# Altium schematic sheet User.SchDoc
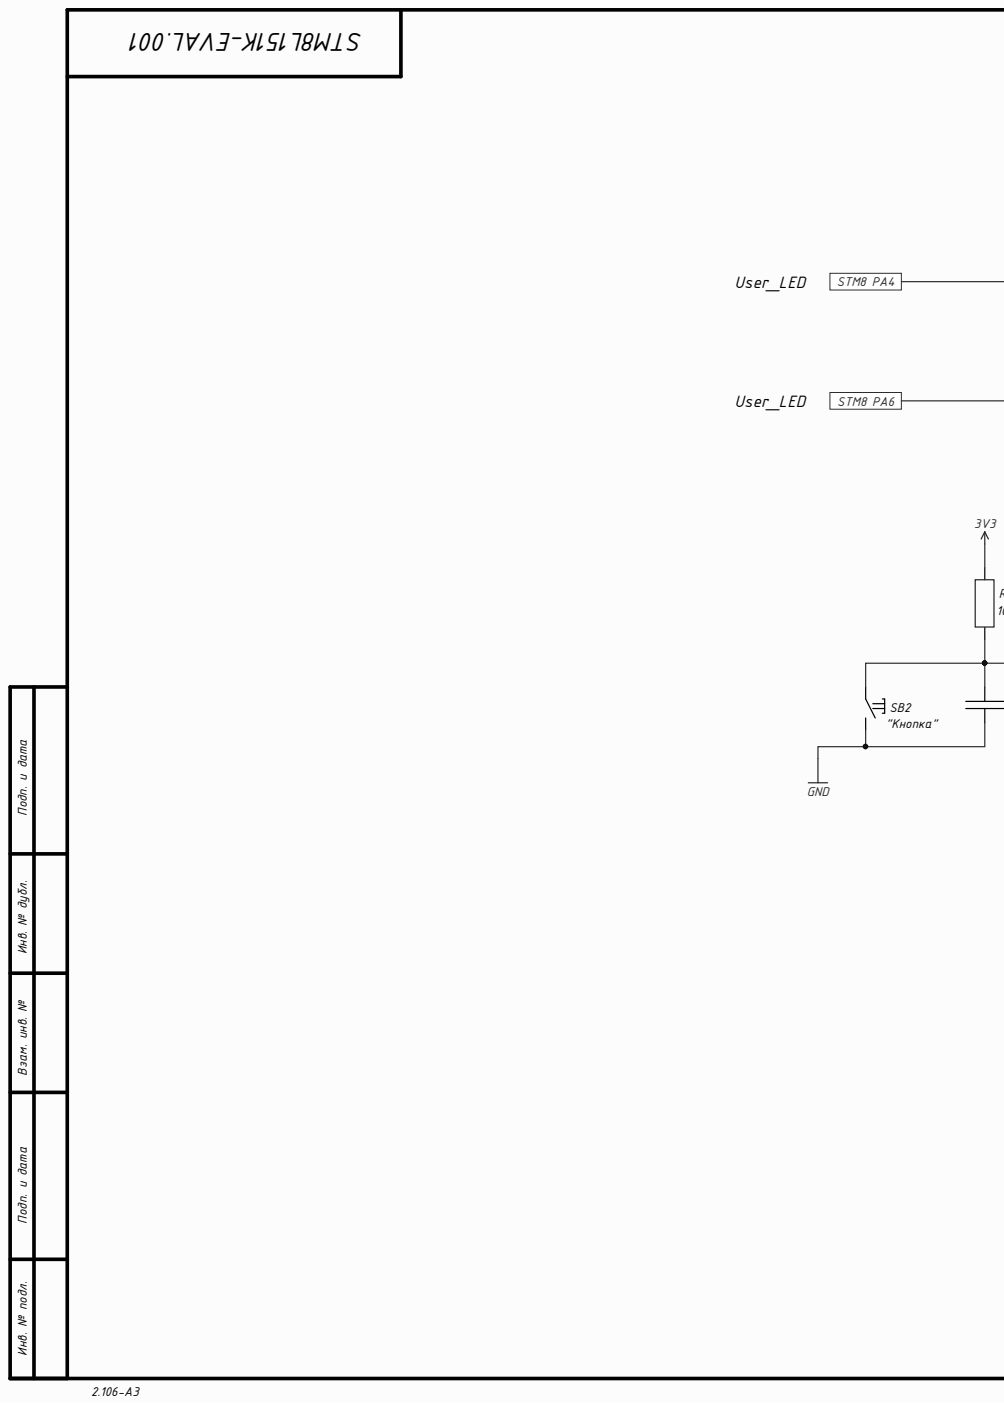
[diagram p0: User.SchDoc, left]
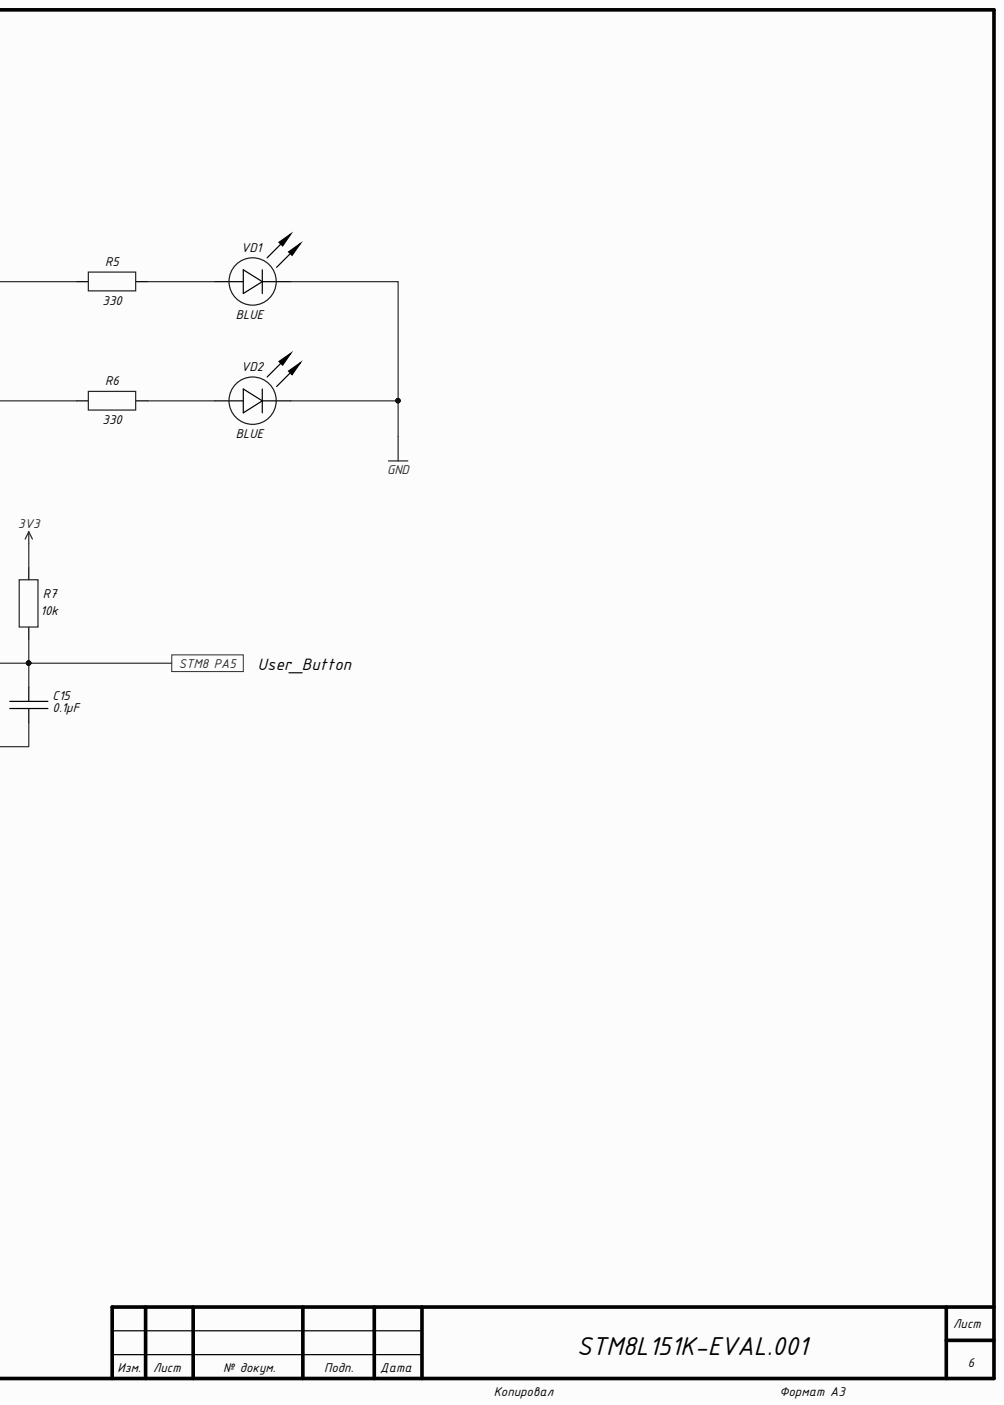
[diagram p1: User.SchDoc, right]

## Parts
C15  0.1µF  [CH0603C]
R5  330  [CH0603R]
R6  330  [CH0603R]
R7  10k  [CH0603R]
SB2  "Кнопка"  [BUT: 4X41.5 SMT]
VD1  BLUE  [CH0603LB]
VD2  BLUE  [CH0603LB]

## Ports
STM8 PA4, STM8 PA5, STM8 PA6

## Nets
3V3: R7.2
GND: C15.1 SB2.2 VD1.2 VD2.2
STM8 PA4: R5.1 ; port STM8 PA4
STM8 PA5: C15.2 R7.1 SB2.1 ; port STM8 PA5
STM8 PA6: R6.1 ; port STM8 PA6
NetR5_2: R5.2 VD1.1
NetR6_2: R6.2 VD2.1

## Library data of the parts
C15 = Capacitor: Component Kind: Standard; Library Name: Discrete.IntLib; Value: 0.1µF
R5-R7 = Resistor: Component Kind: Standard; Library Name: Discrete.IntLib
  R5 R6: Value: 330
  R7: Value: 10k
SB2 = Tactile switches: 6x6 mm; Component Kind: Standard; Library Name: Connectors.IntLib
VD1 VD2 = LED: Component Kind: Standard; Library Name: Discrete.IntLib; Value: BLUE
